# Altium schematic sheet NanoD1_USB.SchDoc
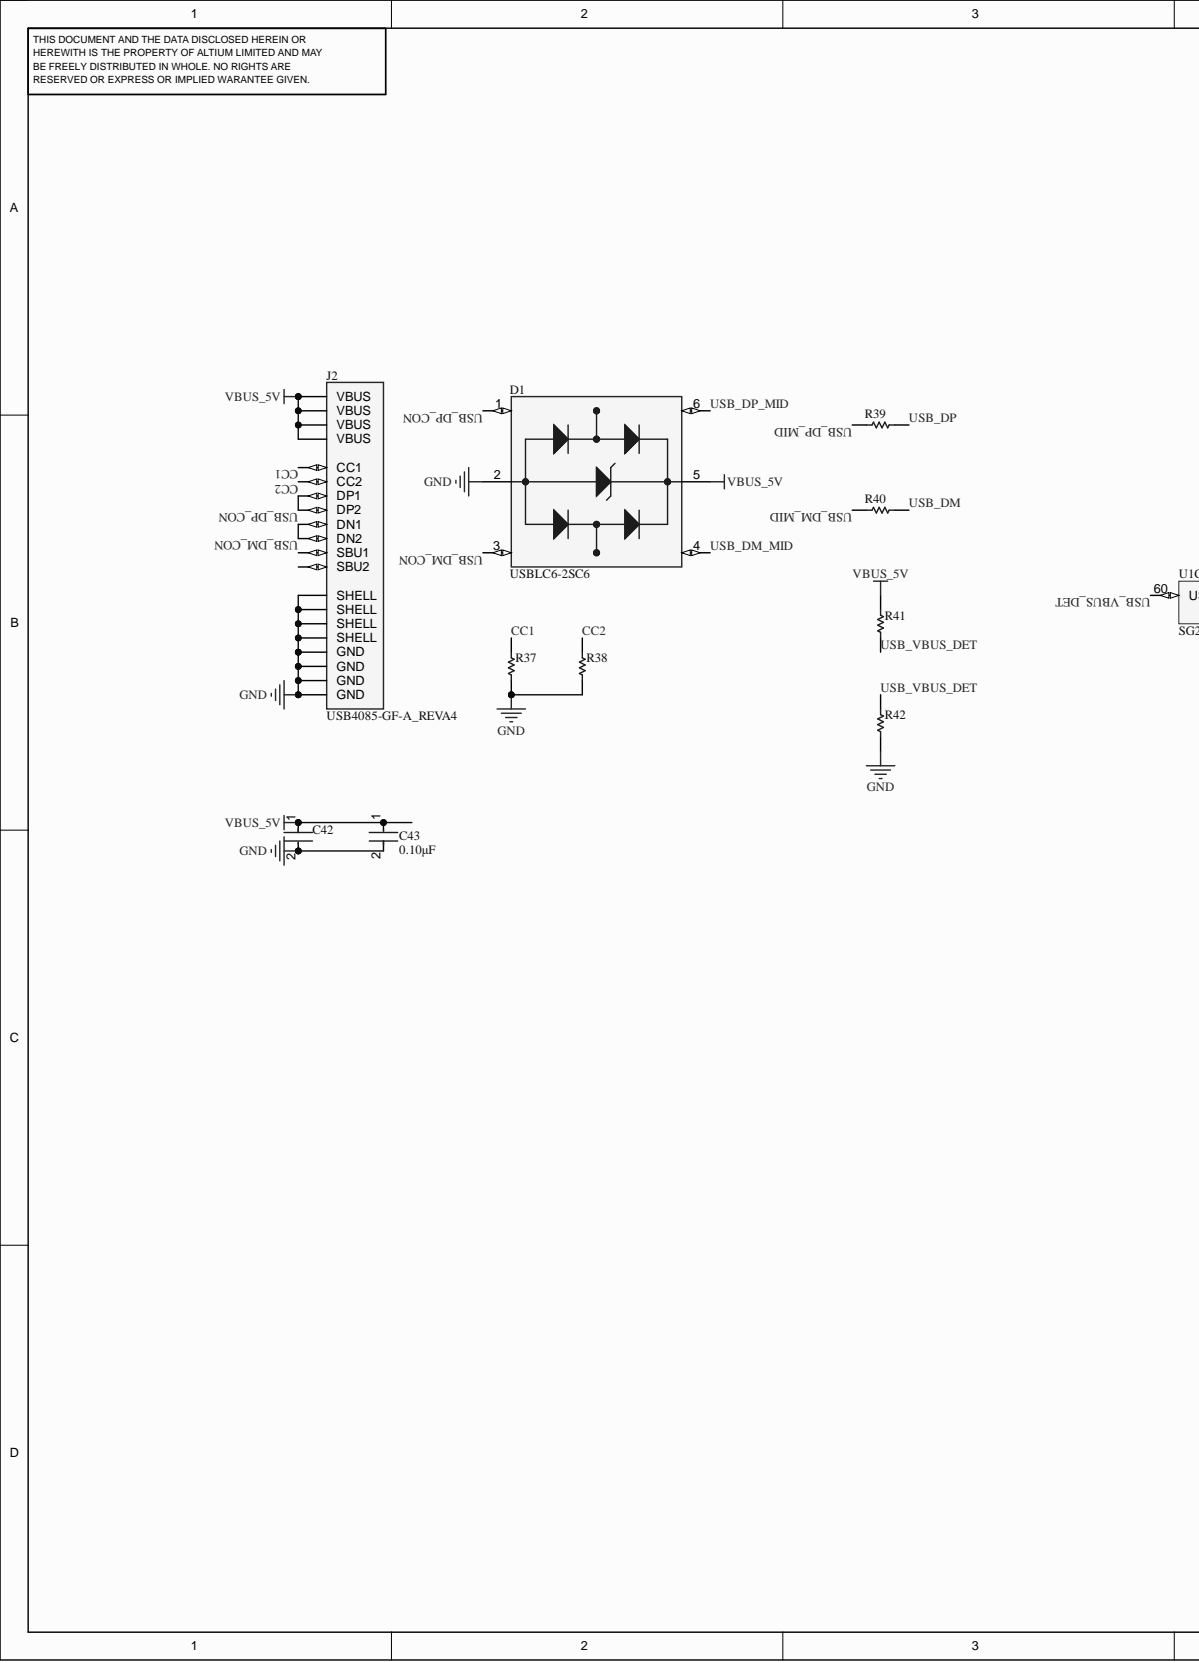
[diagram p0: NanoD1_USB.SchDoc, left]
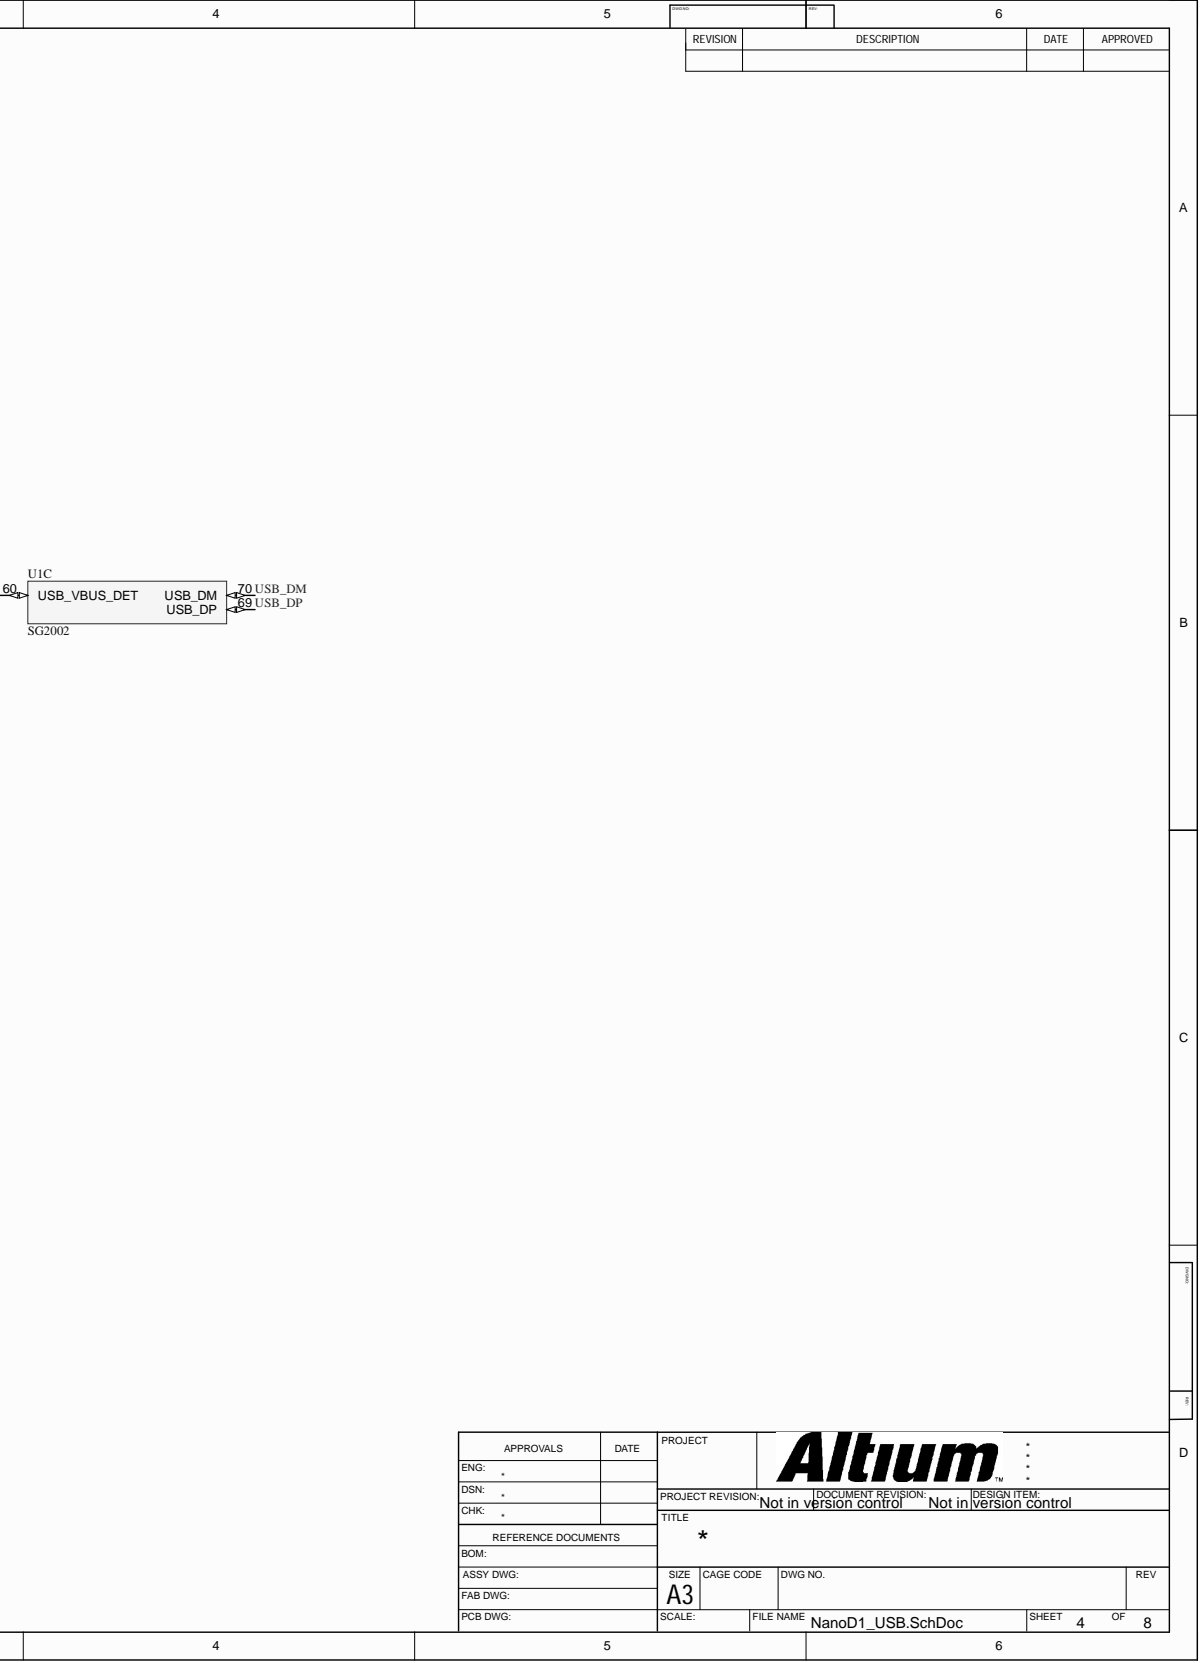
[diagram p1: NanoD1_USB.SchDoc, right]

## Parts
C42  10uF  [FP-GRM188-0_15-IPC_B]  (value not drawn)
C43  value 0.10μF  Capacitance: 0.10μF  [FP-GRM15-MFG]  (hidden comment: 100nF)
D1  USBLC6-2SC6  [SOT95P280X145-6N]
J2  USB4085-GF-A_REVA4  [GCT_USB4085-GF-A_REVA4]
R37  5K1  [RESC1005X40X25ML05T10]  (value not drawn)
R38  5K1  [RESC1005X40X25ML05T10]  (value not drawn)
R39  5R1  [RESC1005X40X25ML05T10]  (value not drawn)
R40  5R1  [RESC1005X40X25ML05T10]  (value not drawn)
R41  100K  [RESC1005X40X25ML05T10]  (value not drawn)
R42  47K  [RESC1005X40X25ML05T10]  (value not drawn)
U1  SG2002  [QFN88-9x9-0.35]  (part C)

## Nets
GND: C42.2 C43.2 D1.2 J2.A1(GND) J2.A12(GND) J2.B1(GND) J2.B12(GND) J2.S1(SHELL) J2.S2(SHELL) J2.S3(SHELL) J2.S4(SHELL) R37.1 R38.1 R42.1
VBUS_5V: C42.1 C43.1 D1.5 J2.A4(VBUS) J2.A9(VBUS) J2.B4(VBUS) J2.B9(VBUS) R41.2
CC1: J2.A5(CC1) R37.2
CC2: J2.B5(CC2) R38.2
USB_DM: R40.1 U1.70(USB_DM)
USB_DM_CON: D1.3 J2.A7(DN1) J2.B7(DN2)
USB_DM_MID: D1.4 R40.2
USB_DP: R39.1 U1.69(USB_DP)
USB_DP_CON: D1.1 J2.A6(DP1) J2.B6(DP2)
USB_DP_MID: D1.6 R39.2
USB_VBUS_DET: R41.1 R42.2 U1.60(USB_VBUS_DET)

Not connected: J2 A8(SBU1) B8(SBU2)

## Library data of the parts
C42 = CMP-06035-003612-1: Chip Multilayer Ceramic Capacitors for General Purpose, 0603, 10uF, X5R, 15%, 20%, 10V; Capacitance: 10uF; Case Code (Imperial): 0603; Case Code (Metric): 1608; Case/Package: 0603; Component Kind: Standard; Depth: 0.8mm; Dielectric: X5R; Height: 0.8mm; Lead Free: Lead Free; Length: 1.6mm; Library Name: GRM188R61A106ME69D.IntLib; Material: Ceramic; Max Operating Temperature: 85°C; Min Operating Temperature: -55°C; Mount: Surface Mount; Package Quantity: 4000; Packaging: Tape and Reel; Termination: SMD/SMT; Thickness: 0.035inch; Tolerance: 20%; Voltage Rating: 10V; Voltage Rating (DC): 10V; Weight: 0.000222oz; Width: 0.8mm
C43 = CMP-2100-03641-2: CAP CER 0.1UF 16V X7R 0402; Manufacturer: Murata; Manufacturer Part Number: GRM155R71C104KA88D; Material: Ceramic; Standoff Height: 0mm; Tolerance: 10%; Voltage Rating: 16Vdc; Category: Cap; Component Kind: Standard; ComponentLink2Description: Datasheet; ComponentLink2URL: https://search.murata.co.jp/Ceramy/image/img/A01X/G101/ENG/GRM155R71H471KA01-01A.pdf; Device Class L1: Passive Components; Device Class L2: Capacitors; Device Class L3: Ceramic Capacitors; Digikey Description: CAP CER 0.1UF 16V X7R 0402; Height: 0.55mm; Ipc Land Pattern Name: CAPC10050X219; Lead Free: yes; Library Name: GRM155R71C104KA88D.IntLib; Mouser Description: 0402 0.1 uF 16V ±10 % Tolerance X7R SMT Multilayer Ceramic Capacitor; Octopart: https://octopart.com/grm155r71c104ka88d-murata-196880; Package: GRM15; RoHS: TRUE; Temperature: -55°C to +125°C; Temperature Characteristic: X7R; Temperature Range High: +125°C; Temperature Range Low: -55°C
D1 = USBLC6-2SC6: 17V Clamp 5A (8/20Âµs) Ipp Tvs Diode Surface Mount SOT-23-6; MF: STMicroelectronics; Availability: In Stock; Check_prices: https://www.snapeda.com/parts/USBLC6-2SC6/STMicroelectronics/view-part/?ref=eda; Component Kind: Standard; Library Name: USBLC6-2SC6.IntLib; MP: USBLC6-2SC6; Package: SOT-23-6 STMicroelectronics; Price: None; Purchase-URL: https://pricing.snapeda.com/search/part/USBLC6-2SC6/?ref=eda; SnapEDA_Link: https://www.snapeda.com/parts/USBLC6-2SC6/STMicroelectronics/view-part/?ref=snap
J2 = USB4085-GF-A_REVA4: USB-C (USB TYPE-C) USB 2.0 Receptacle Connector 24 (16+8 Dummy) Position Through Hole, Right Angle, low cost, 3.46mm profile, 16 pin, horizontal, Top mount; MF: Global Connector Technology; Availability: In Stock; Check_prices: https://www.snapeda.com/parts/USB4085-GF-A/Global+Connector+Technology/view-part/?ref=eda; Component Kind: Standard; Library Name: USB4085-GF-A_REVA4.IntLib; MP: USB4085-GF-A; Package: None; Price: None; Purchase-URL: https://pricing.snapeda.com/search/part/USB4085-GF-A/?ref=eda; SnapEDA_Link: https://www.snapeda.com/parts/USB4085-GF-A/Global+Connector+Technology/view-part/?ref=snap
R37 R38 = CMP-2002-07753-1: Manufacturer: Yageo / Phycomp; Part Number: RC0402FR-075K1L; Component Kind: Standard; Library Name: RC0402FR-075K1L.IntLib
R39 R40 = CMP-2002-07068-1: Manufacturer: Yageo / Phycomp; Part Number: RC0402FR-075R1L; Component Kind: Standard; Library Name: RC0402FR-075R1L.IntLib
R41 = CMP-2002-07343-1: Manufacturer: Yageo / Phycomp; Part Number: RC0402FR-07100KL; Component Kind: Standard; Library Name: RC0402FR-07100KL.IntLib
R42 = CMP-2002-07701-1: Manufacturer: Yageo / Phycomp; Part Number: RC0402FR-0747KL; Component Kind: Standard; Library Name: RC0402FR-0747KL.IntLib
U1 = SG2002: RISC-V C906 / Cortex-A53 SoC, 256MB DDR3 SiP, QFN88 9x9 0.35mm; Component Kind: Standard; Library Name: NanoD1.SchLib
